# Altium schematic sheet controller.SchDoc(controller)
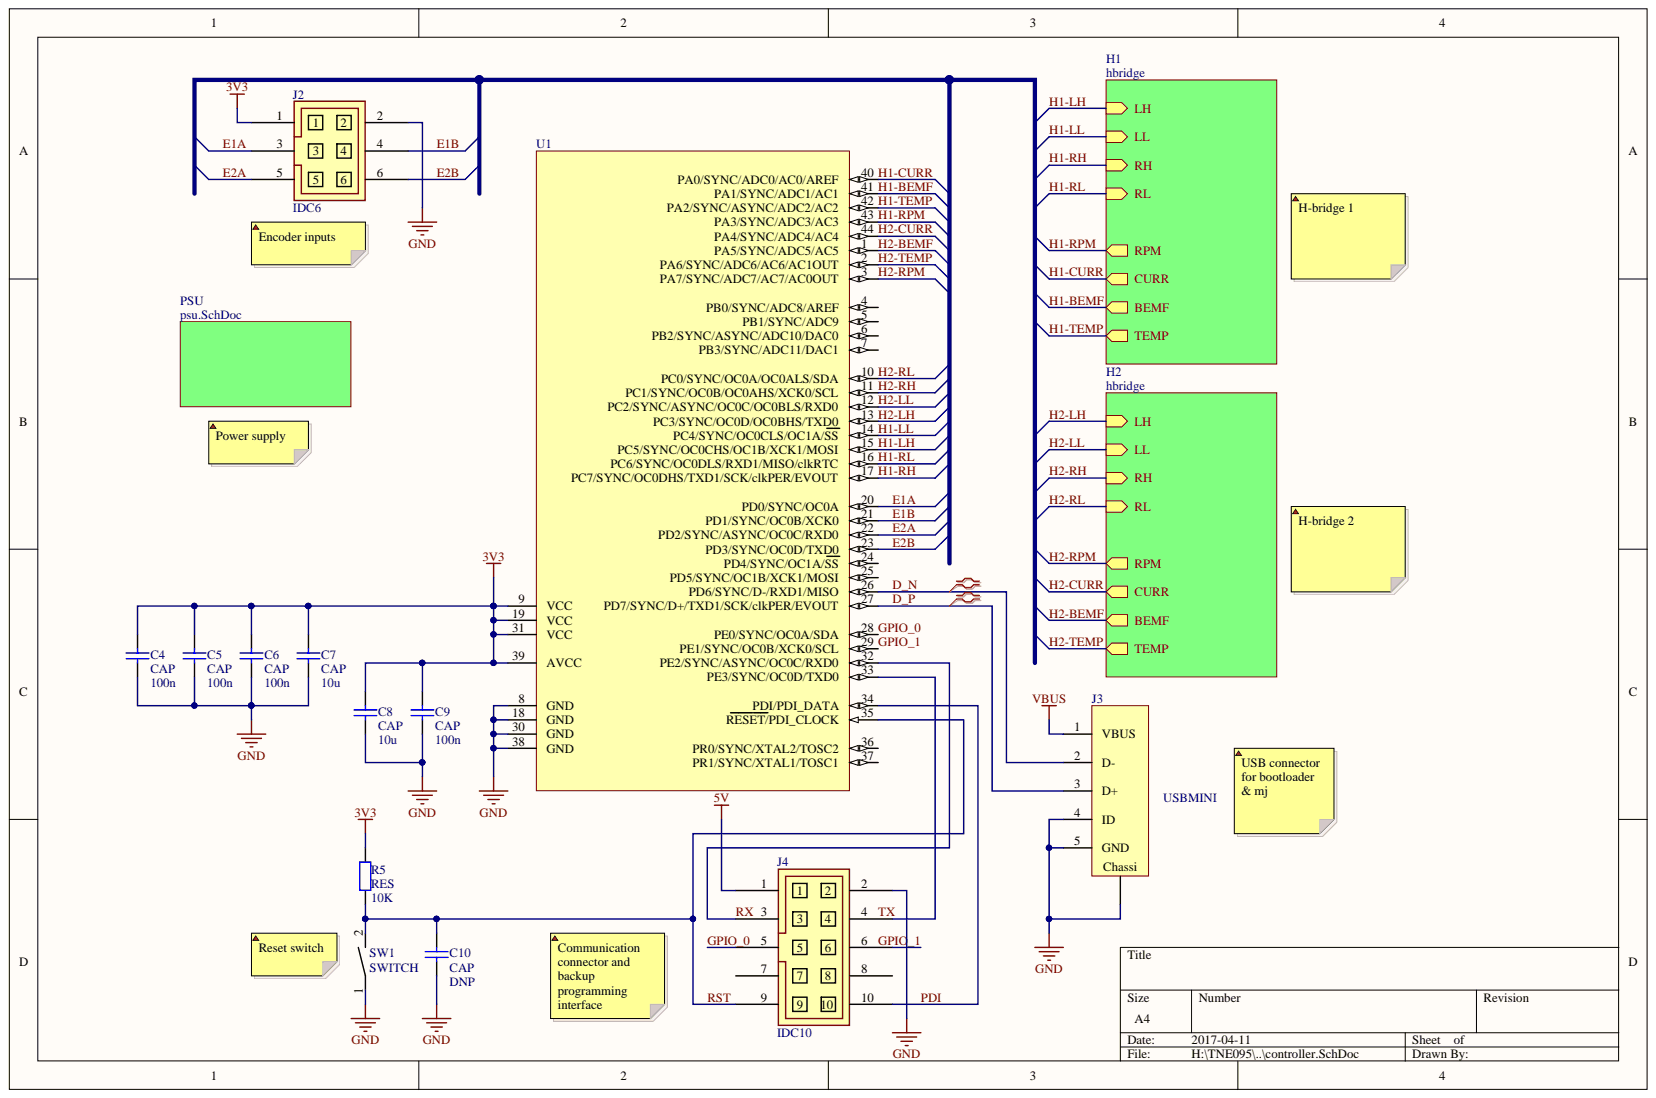
[diagram p0: controller.SchDoc]

## Parts
C4  CAP  value 100n  [CAPC1608X08M]
C5  CAP  value 100n  [CAPC1608X08M]
C6  CAP  value 100n  [CAPC1608X08M]
C7  CAP  value 10u  [CAPC1608X08M]
C8  CAP  value 10u  [CAPC2012X11M]
C9  CAP  value 100n  [CAPC1608X08M]
C10  CAP  value DNP  [CAPC1608X08M]
J2  IDC6  [IDC6]
J3  USBMINI  [MINI_USB]
J4  IDC10  [IDC10]
R5  RES  value 10K  [RESC1608X06M]
SW1  SWITCH  [Switch_pad]
U1  (no value)  [44A_N]

## Nets
3V3: C4.2 C5.2 C6.2 C7.2 C8.2 C9.2 J2.1 R5.2 U1.9(VCC) U1.19(VCC) U1.31(VCC) U1.39(AVCC)
5V: J4.1
GND: C4.1 C5.1 C6.1 C7.1 C8.1 C9.1 C10.1 J2.2 J3.4(ID) J3.5(GND) J3.C J4.2 SW1.1 U1.8(GND) U1.18(GND) U1.30(GND) U1.38(GND)
VBUS: J3.1(VBUS)
D_N: J3.2(D-) U1.26(PD6/SYNC/D-/RXD1/MISO)
D_P: J3.3(D+) U1.27(PD7/SYNC/D+/TXD1/SCK/clkPER/EVOUT)
E1A: J2.3 U1.20(PD0/SYNC/OC0A)
E1B: J2.4 U1.21(PD1/SYNC/OC0B/XCK0)
E2A: J2.5 U1.22(PD2/SYNC/ASYNC/OC0C/RXD0)
E2B: J2.6 U1.23(PD3/SYNC/OC0D/TXD0)
GPIO_0: J4.5 U1.28(PE0/SYNC/OC0A/SDA)
GPIO_1: U1.29(PE1/SYNC/OC0B/XCK0/SCL)
H1-BEMF: U1.41(PA1/SYNC/ADC1/AC1)
H1-CURR: U1.40(PA0/SYNC/ADC0/AC0/AREF)
H1-LH: U1.15(PC5/SYNC/OC0CHS/OC1B/XCK1/MOSI)
H1-LL: U1.14(PC4/SYNC/OC0CLS/OC1A/SS)
H1-RH: U1.17(PC7/SYNC/OC0DHS/TXD1/SCK/clkPER/EVOUT)
H1-RL: U1.16(PC6/SYNC/OC0DLS/RXD1/MISO/clkRTC)
H1-RPM: U1.43(PA3/SYNC/ADC3/AC3)
H1-TEMP: U1.42(PA2/SYNC/ASYNC/ADC2/AC2)
H2-BEMF: U1.1(PA5/SYNC/ADC5/AC5)
H2-CURR: U1.44(PA4/SYNC/ADC4/AC4)
H2-LH: U1.13(PC3/SYNC/OC0D/OC0BHS/TXD0)
H2-LL: U1.12(PC2/SYNC/ASYNC/OC0C/OC0BLS/RXD0)
H2-RH: U1.11(PC1/SYNC/OC0B/OC0AHS/XCK0/SCL)
H2-RL: U1.10(PC0/SYNC/OC0A/OC0ALS/SDA)
H2-RPM: U1.3(PA7/SYNC/ADC7/AC7/AC0OUT)
H2-TEMP: U1.2(PA6/SYNC/ADC6/AC6/AC1OUT)
PDI: J4.10 U1.34(PDI/PDI_DATA)
RST: C10.2 J4.9 R5.1 SW1.2 U1.35(RESET/PDI_CLOCK)
RX: J4.3 U1.32(PE2/SYNC/ASYNC/OC0C/RXD0)
NetJ4_4: J4.4 U1.33(PE3/SYNC/OC0D/TXD0)
NetJ4_6: J4.6
NetJ4_7: J4.7
NetJ4_8: J4.8
NetU1_4: U1.4(PB0/SYNC/ADC8/AREF)
NetU1_5: U1.5(PB1/SYNC/ADC9)
NetU1_6: U1.6(PB2/SYNC/ASYNC/ADC10/DAC0)
NetU1_7: U1.7(PB3/SYNC/ADC11/DAC1)
NetU1_24: U1.24(PD4/SYNC/OC1A/SS)
NetU1_25: U1.25(PD5/SYNC/OC1B/XCK1/MOSI)
NetU1_36: U1.36(PR0/SYNC/XTAL2/TOSC2)
NetU1_37: U1.37(PR1/SYNC/XTAL1/TOSC1)
N00028:
TX:

## Library data of the parts
C4-C10 = CAP: Capacitor; Component Kind: Standard; LatestRevisionDate: 17-Jul-2002; LatestRevisionNote: Re-released for DXP Platform.; Library Name: std.SchLib; PackageDescription: Polarized Capacitor (Radial); 2 Leads; PackageReference: RB7.6-15; Published: 8-Jun-2000; Publisher: Altium Limited
J2 = IDC6: 6pin IDC connector; Component Kind: Standard; Library Name: std.SchLib
J3 = MINI_USB: Component Kind: Standard; Library Name: std.SchLib
J4 = IDC10: 10pin IDC connector; Component Kind: Standard; Library Name: std.SchLib
R5 = RES: Resistor; Component Kind: Standard; LatestRevisionDate: 17-Jul-2002; LatestRevisionNote: Re-released for DXP Platform.; Library Name: std.SchLib; PackageDescription: Axial Device, Thru-Hole; 2 Leads; 0.4 in Pin Spacing; PackageReference: AXIAL-0.4; Published: 8-Jun-2000; Signal Integrity: Res; Simulation: RESISTOR; Publisher: Altium Limited
U1 = ATxmega32A4U-AU: 8/16-bit AVR XMEGA A4U Microcontroller, 1.6-3.6V, 32MHz, 32K + 4KB Flash, 1K EEPROM, 4K SRAM, 44-pin TQFP, Industrial Grade (-40°C to 85°C); Code_JEDEC: MS-026-ACB; Component Kind: Standard; ComponentLink1Description: Manufacturer URL; ComponentLink1URL: http://www.atmel.com/; ComponentLink2Description: ATxmega16A4U/32A4U/64A4U/128A4U Datasheet; ComponentLink2URL: http://www.atmel.com/dyn/resources/prod_documents/doc8387.pdf; DatasheetVersion: revB, Dec-2011; Library Name: Atmel Microcontroller AVR XMEGA.IntLib; PackageDescription: 44-Pin Plastic Thin Profile Quad Flat Pack, 10 x 10 x 1.0 mm Body, 0.8 mm Pitch (TQFP); PackageReference: 44A; PackageVersion: revC, Oct-2010
SW1 = Switch: Component Kind: Standard; Description: Switch; Library Name: std.SchLib
